# Altium schematic sheet External-Upper.SchDoc
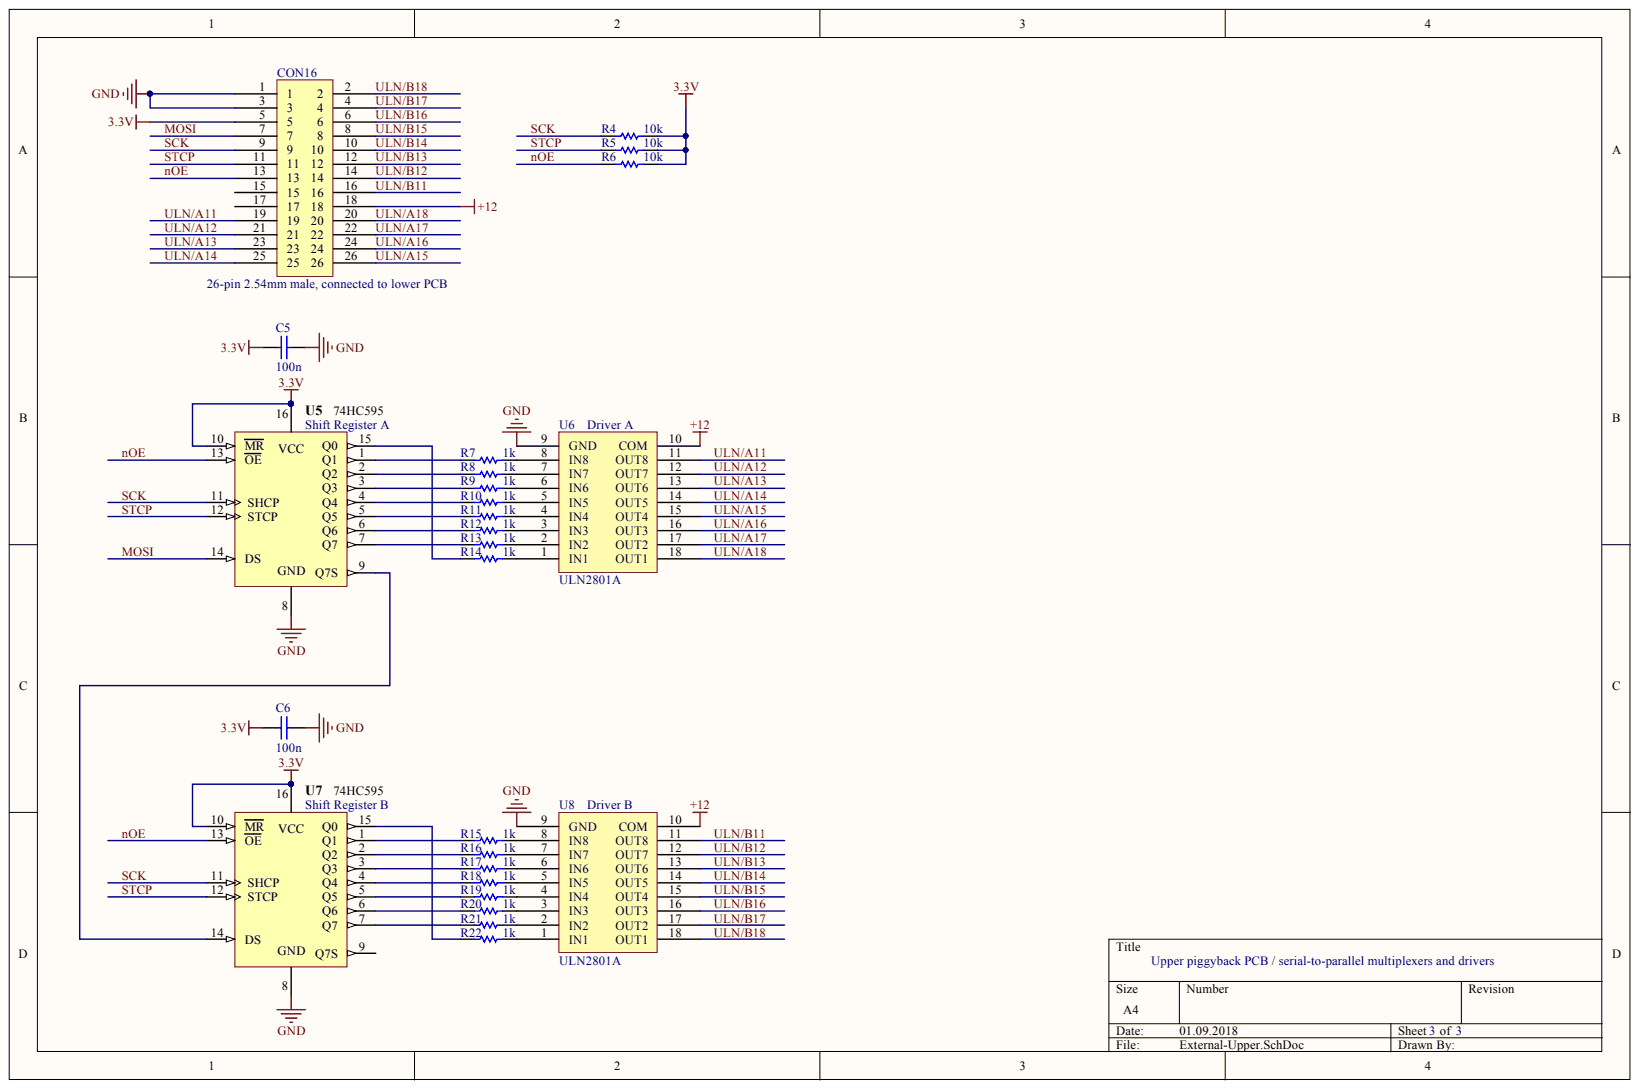
[diagram p0: External-Upper.SchDoc]

## Parts
C5  100n  [PCB-xlqz8i8lofgldnfjb9dw-1]
C6  100n  [PCB-xlqz8i8lofgldnfjb9dw-1]
CON16  26-pin 2.54mm male, connected to lower PCB  [PCB-anyg1stvhu39ted5u9rh-1]
R4  10k  [RESC2012X07L]
R5  10k  [RESC2012X07L]
R6  10k  [RESC2012X07L]
R7  1k  [RESC2012X07L]
R8  1k  [RESC2012X07L]
R9  1k  [RESC2012X07L]
R10  1k  [RESC2012X07L]
R11  1k  [RESC2012X07L]
R12  1k  [RESC2012X07L]
R13  1k  [RESC2012X07L]
R14  1k  [RESC2012X07L]
R15  1k  [RESC2012X07L]
R16  1k  [RESC2012X07L]
R17  1k  [RESC2012X07L]
R18  1k  [RESC2012X07L]
R19  1k  [RESC2012X07L]
R20  1k  [RESC2012X07L]
R21  1k  [RESC2012X07L]
R22  1k  [RESC2012X07L]
U5  74HC595  [PCB-2cjlbytggwel4yc30wx0-1]
U6  ULN2801A  [PCB-rwjocf2rxqt1zenc8oqy-1]
U7  74HC595  [PCB-2cjlbytggwel4yc30wx0-1]
U8  ULN2801A  [PCB-rwjocf2rxqt1zenc8oqy-1]

## Nets
3.3V: C5.1 C6.1 CON16.5 R4.1 R5.1 R6.1 U5.10(MR) U5.16 U7.10(MR) U7.16
+12: CON16.18 U6.10(COM) U8.10(COM)
GND: C5.2 C6.2 CON16.1 CON16.3 U5.8 U6.9(GND) U7.8 U8.9(GND)
MOSI: CON16.7 U5.14(DS)
NOE: CON16.13 R6.2 U5.13(OE) U7.13(OE)
SCK: CON16.9 R4.2 U5.11(SHCP) U7.11(SHCP)
STCP: CON16.11 R5.2 U5.12(STCP) U7.12(STCP)
ULN/A11: CON16.19 U6.11(OUT8)
ULN/A12: CON16.21 U6.12(OUT7)
ULN/A13: CON16.23 U6.13(OUT6)
ULN/A14: CON16.25 U6.14(OUT5)
ULN/A15: CON16.26 U6.15(OUT4)
ULN/A16: CON16.24 U6.16(OUT3)
ULN/A17: CON16.22 U6.17(OUT2)
ULN/A18: CON16.20 U6.18(OUT1)
ULN/B11: CON16.16 U8.11(OUT8)
ULN/B12: CON16.14 U8.12(OUT7)
ULN/B13: CON16.12 U8.13(OUT6)
ULN/B14: CON16.10 U8.14(OUT5)
ULN/B15: CON16.8 U8.15(OUT4)
ULN/B16: CON16.6 U8.16(OUT3)
ULN/B17: CON16.4 U8.17(OUT2)
ULN/B18: CON16.2 U8.18(OUT1)
NetR7_1: R7.1 U6.8(IN8)
NetR7_2: R7.2 U5.1(Q1)
NetR8_1: R8.1 U6.7(IN7)
NetR8_2: R8.2 U5.2(Q2)
NetR9_1: R9.1 U6.6(IN6)
NetR9_2: R9.2 U5.3(Q3)
NetR10_1: R10.1 U6.5(IN5)
NetR10_2: R10.2 U5.4(Q4)
NetR11_1: R11.1 U6.4(IN4)
NetR11_2: R11.2 U5.5(Q5)
NetR12_1: R12.1 U6.3(IN3)
NetR12_2: R12.2 U5.6(Q6)
NetR13_1: R13.1 U6.2(IN2)
NetR13_2: R13.2 U5.7(Q7)
NetR14_1: R14.1 U6.1(IN1)
NetR14_2: R14.2 U5.15(Q0)
NetR15_1: R15.1 U8.8(IN8)
NetR15_2: R15.2 U7.1(Q1)
NetR16_1: R16.1 U8.7(IN7)
NetR16_2: R16.2 U7.2(Q2)
NetR17_1: R17.1 U8.6(IN6)
NetR17_2: R17.2 U7.3(Q3)
NetR18_1: R18.1 U8.5(IN5)
NetR18_2: R18.2 U7.4(Q4)
NetR19_1: R19.1 U8.4(IN4)
NetR19_2: R19.2 U7.5(Q5)
NetR20_1: R20.1 U8.3(IN3)
NetR20_2: R20.2 U7.6(Q6)
NetR21_1: R21.1 U8.2(IN2)
NetR21_2: R21.2 U7.7(Q7)
NetR22_1: R22.1 U8.1(IN1)
NetR22_2: R22.2 U7.15(Q0)
NetU5_9: U5.9(Q7S) U7.14(DS)

Not connected: CON16 15 17; U7 9(Q7S)

## Library data of the parts
C5 C6 = K222K10X7RF5UH5: Cap Ceramic 0.0022uF 50V X7R 10% Radial 5mm 125°C Ammo Pack; Capacitance: 2.20 nF; Voltage Rating (DC): 50.0 V; Capacitance Tolerance: ±10%; Component Kind: Standard; Dielectric Characteristic: X7R; Library Name: Octopart library; Mounting Style: Through Hole; OctopartID: acaf652899037368; Packaging: Cut Tape (CT)
CON16 = 5499922-6: Pin strip + ejector (long) Contact spacing: 2.54 mm Total number of pins: 26 TE Connectivity 1 pc(s); Current Rating: 1.00 A; ELV Compliant: Compliant; Lead-Free Status: Lead Free; Mounting Angle: 180 °; Number of Mating Cycles: 50; Number of Positions: 26; Color: Black; Component Kind: Standard; Contact Plating: Gold, Tin; Flammability Rating: UL 94 V-0; Gender: Male; Housing Color: Black; Housing Material: Thermoplastic; Library Name: Octopart library; Manufacturer: TE Connectivity; Manufacturer Part Number: 5499922-6; Mounting Style: Through Hole, Vertical; Number of Rows: 2; OctopartID: ed6f263ec2da32a8; Octopart URL: http://octopart.com/5499922-6-te+connectivity+%2F+amp-39520979; Orientation: Vertical; Packaging: Tray; Pin Pitch: 2.54 mm; RoHS: Compliant; Size-Height: 13.9 mm; Size-Length: 52.3 mm; Size-Width: 8.53 mm
R4-R22 = RP73PF2A12K1BTDF: Surface Mount Thin Film Resistor, RP73 Series, 12.1 kohm, 250 mW, - 0.1%, 150 V; Power Rating: 250 mW; Resistance: 12.1 kΩ; Voltage Rating (DC): 150 V; Case/Package: 0805, 2012; Component Kind: Standard; Library Name: Octopart library; Lifecycle Status: Not Listed by Manufacturer; Manufacturer Part Number: RP73PF2A12K1BTDF; Mounting Style: Surface Mount; OctopartID: 56ed9d52b0ae892a; Octopart URL: http://octopart.com/rp73pf2a12k1btdf-te+connectivity-45466405; Packaging: Cut Tape (CT); REACH SVHC Compliance: No SVHC; Resistance Tolerance: ±0.1%; RoHS: Compliant
U5 U7 = 74HC595D,118: 74HC Series 6 V 3-State 8-Bit Serial-In Serial or Parallel-Out Shift Register; Frequency: 100 MHz; Lead-Free Status: Lead Free; Component Kind: Standard; Library Name: Octopart library; Octopart URL: http://octopart.com/74hc595d%2C118-nxp+semiconductors-10475494
U6 U8 = ULN2803A: Bipolar (BJT) Array Transistor, Darlington, NPN, 50 V, 2.25 W, 500 mA, 1000, DIP; Current Rating: 500 mA; IC Socket: AE9995-ND; Input Capacitance: 15.0 pF; Number of Channels: 8; Output Voltage: 50.0 V; Polarity: NPN, N-Channel; Case/Package: DIP; Component Kind: Standard; Library Name: Octopart library; Lifecycle Status: Active; Manufacturer Part Number: ULN2803A; Mounting Style: Through Hole; Number of Pins: 18; OctopartID: d6cc1c8ba064090b; Packaging: Tube; REACH SVHC Compliance: No SVHC; RoHS: Compliant
